# Altium schematic sheet PowerSupply.SchDoc(PowerSupply)
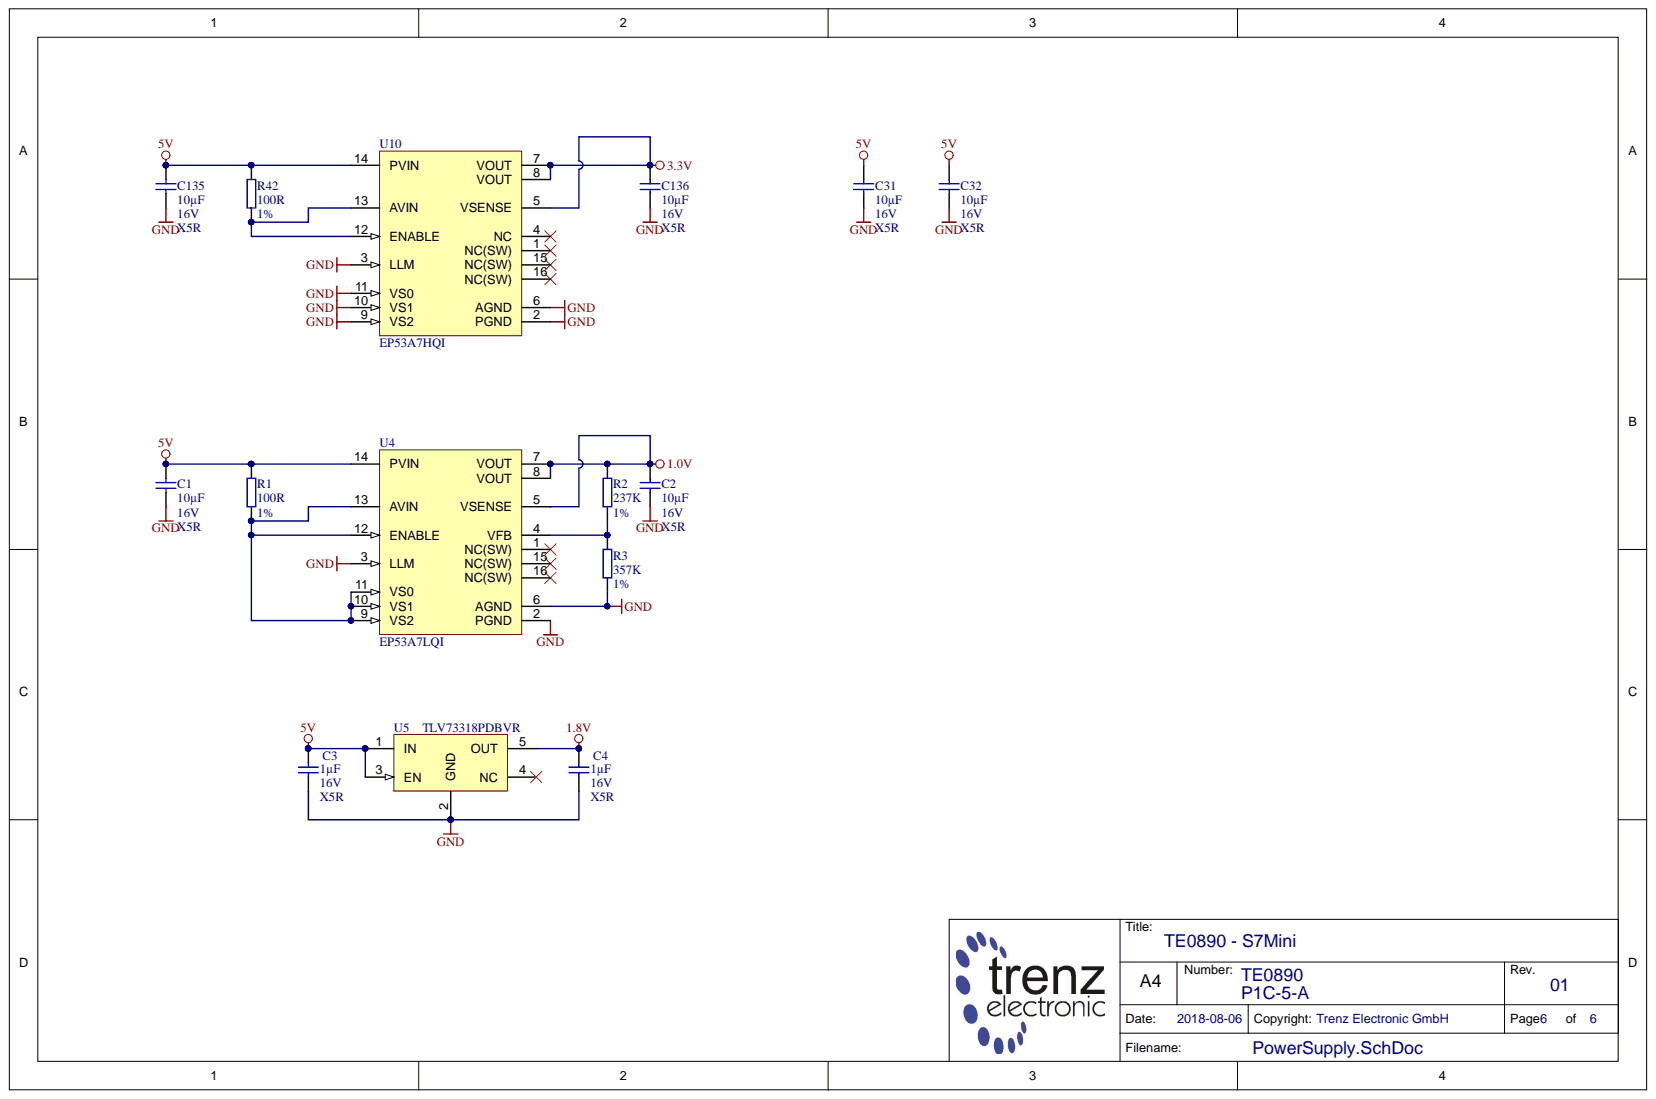
[diagram p0: PowerSupply.SchDoc]

## Parts
C1  10µF  Voltage: 16V  Technology: X5R  [CAPC1608X90N]
C2  10µF  Voltage: 16V  Technology: X5R  [CAPC1608X90N]
C3  1µF  Voltage: 16V  Technology: X5R  [CAPC1005X55N]
C4  1µF  Voltage: 16V  Technology: X5R  [CAPC1005X55N]
C31  10µF  Voltage: 16V  Technology: X5R  [CAPC1608X90N]
C32  10µF  Voltage: 16V  Technology: X5R  [CAPC1608X90N]
C135  10µF  Voltage: 16V  Technology: X5R  [CAPC1608X90N]
C136  10µF  Voltage: 16V  Technology: X5R  [CAPC1608X90N]
R1  100R  Tolerance: 1%  [RESC1005X40N]
R2  237K  Tolerance: 1%  [RESC1005X40N]
R3  357K  Tolerance: 1%  [RESC1005X40N]
R42  100R  Tolerance: 1%  [RESC1005X40N]
U4  EP53A7LQI  [EP53F8QI]
U5  TLV73318PDBVR  [SOT95P280X145-5N]
U10  EP53A7HQI  [EP53F8QI]

## Nets
1.0V: C2.2 R2.1 U4.5(VSENSE) U4.7(VOUT) U4.8(VOUT)
1.8V: C4.1 U5.5(OUT)
3.3V: C136.2 U10.5(VSENSE) U10.7(VOUT) U10.8(VOUT)
5V: C1.2 C3.1 C31.2 C32.2 C135.2 R1.1 R42.1 U4.14(PVIN) U5.1(IN) U5.3(EN) U10.14(PVIN)
GND: C1.1 C2.1 C3.2 C4.2 C31.1 C32.1 C135.1 C136.1 R3.2 U4.2(PGND) U4.3(LLM) U4.6(AGND) U5.2(GND) U10.2(PGND) U10.3(LLM) U10.6(AGND) U10.9(VS2) U10.10(VS1) U10.11(VS0)
NetR1_2: R1.2 U4.9(VS2) U4.10(VS1) U4.11(VS0) U4.12(ENABLE) U4.13(AVIN)
NetR2_2: R2.2 R3.1 U4.4(VFB)
NetR42_2: R42.2 U10.12(ENABLE) U10.13(AVIN)

Not connected: U4 1(NC(SW)) 15(NC(SW)) 16(NC(SW)); U5 4(NC); U10 1(NC(SW)) 4(NC) 15(NC(SW)) 16(NC(SW))

## Library data of the parts
C1 C2 C31 C32 C135 C136 = 25341: KON 10µF 16V X5R 20% SMD BF 1608 (0603); Value: 10u; Temperature-Range: -55/+85; Preferred: Yes; Mixed: SMT; Database Table Name: C_1608_0603; Tolerance: 20%; Library Name: TE.DbLib; Component Kind: Standard; Case: 1608 (0603); AN: 25341
C3 C4 = 26579: KON 1µF 16V X5R 10% SMD BF 1005 (0402); Value: 1u; Temperature-Range: -55/+85; Mixed: SMT; Database Table Name: C_1005_0402; Tolerance: 10%; Library Name: TE.DbLib; Component Kind: Standard; Case: 1005 (0402); AN: 26579
R1 R42 = 22507: WID SMD 100R 1% 0,063W  BF 1005 (0402); Value: 100; Preferred: Yes; Mixed: SMT; H-ART: RC0402FR-13100RL; Database Table Name: R_1005_0402; Technology: TK100; Library Name: TE.DbLib; Component Kind: Standard; Case: 1005 (0402); AN: 22507
R2 = 24549: WID SMD 237K 1% 0,063W; Preferred: Yes; Mixed: SMT; H-ART: RC0402FR-07237KL; Database Table Name: R_1005_0402; Value: 237K; Technology: TK100; Library Name: TE.DbLib; Component Kind: Standard; Case: 1005 (0402); AN: 24549
R3 = 25437: WID SMD 357K 1% 0,063W; Preferred: Yes; Mixed: SMT; Database Table Name: R_1005_0402; Value: 357k; Technology: TK100; Library Name: TE.DbLib; Component Kind: Standard; Case: 1005 (0402); AN: 25437
U4 = 28280: Trenz-Stock: Yes; Mixed: SMT; Database Table Name: DCDC; Preferred: Yes; Library Name: TE.DbLib; H-ART: EP53A7LQI; Description: EP53A7LQI; Component Kind: Standard; Case: QFN16; AN: 28280; HelpURL: B:\AD\adlib\datasheet\EP53A7HQI
U5 = 28418: TLV73318PDBV; Voltage: 1.8V; Mixed: SMT; Database Table Name: LREG; Library Name: TE.DbLib; H-ART: TLV73318PDBV; Component Kind: Standard; Case: SOT23-3; AN: 28418; HelpURL: b:\AD\adlib\datasheet\TLV733xx\
U10 = 27996: Trenz-Stock: Yes; Mixed: SMT; Database Table Name: DCDC; Preferred: Yes; Library Name: TE.DbLib; H-ART: EP53A7HQI; Description: EP53A7HQI; Component Kind: Standard; Case: QFN16; AN: 27996; HelpURL: B:\AD\adlib\datasheet\EP53A7HQI
